# Altium schematic sheet MSP430G2553.SchDoc
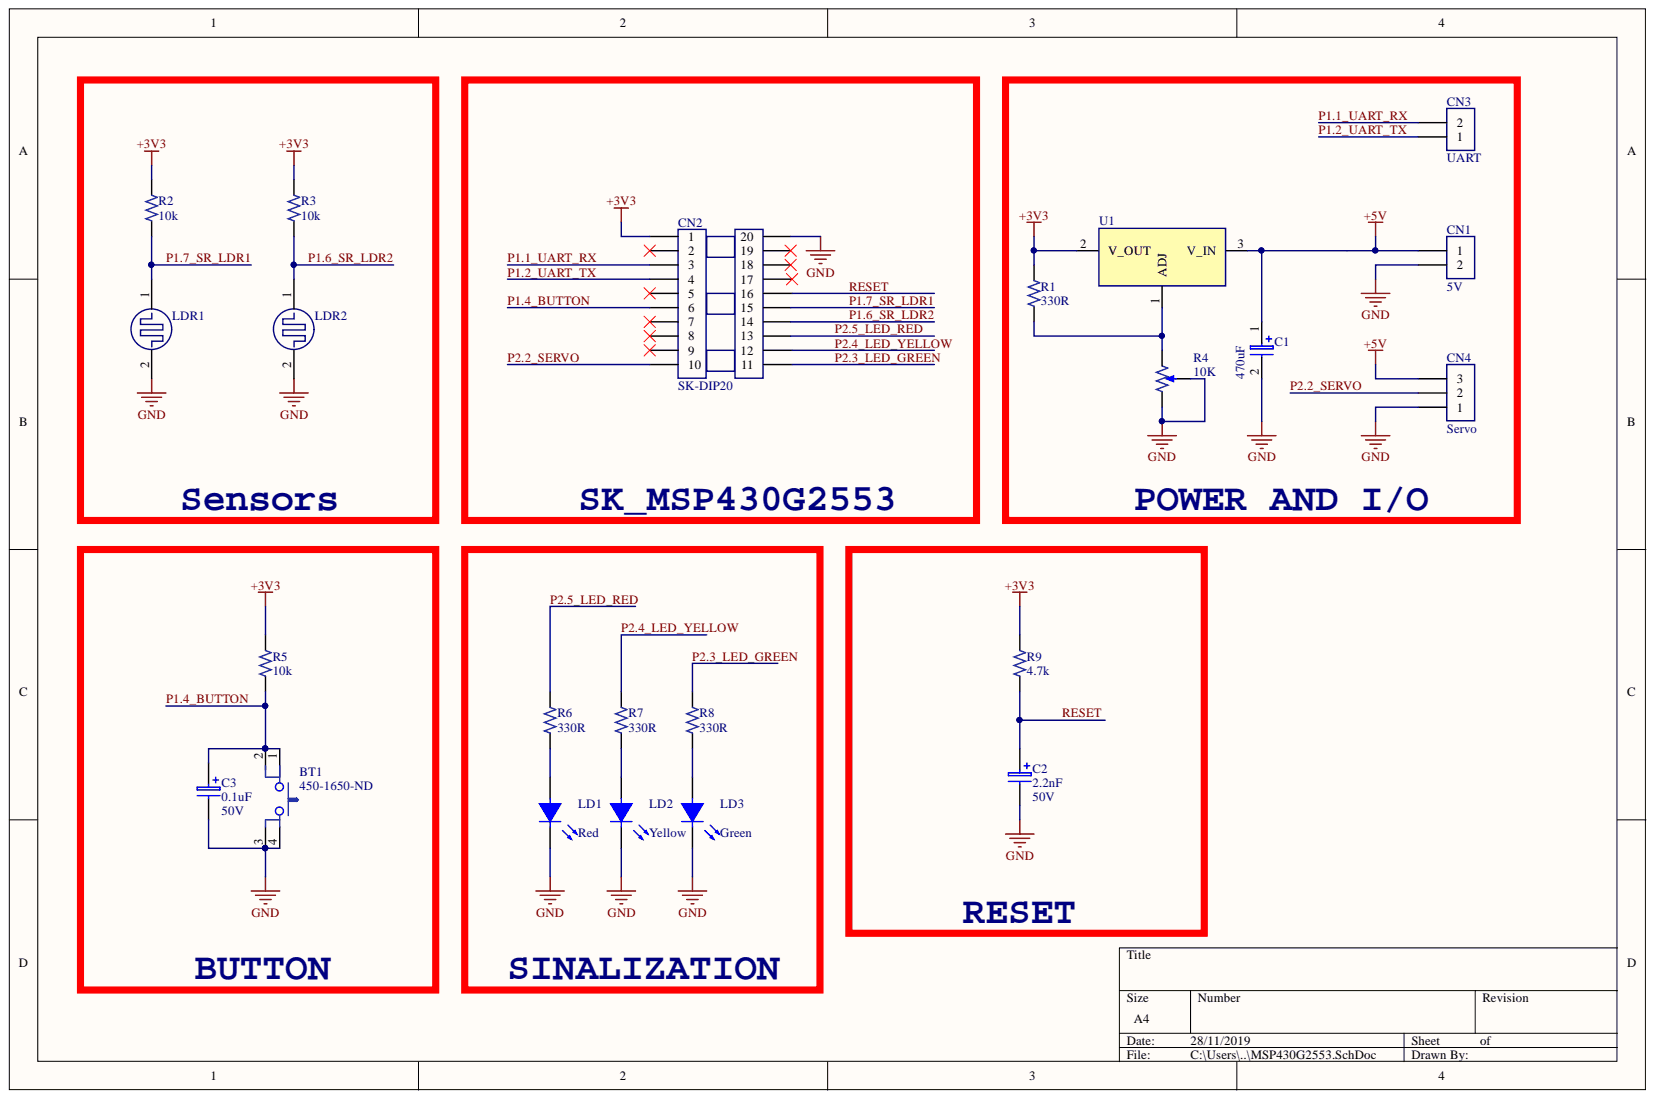
[diagram p0: MSP430G2553.SchDoc]

## Parts
BT1  450-1650-ND  [CH-NA-4P-PTH-430H600L660W-450P-3D]
C1  470uF  [CAP-RADIAL-105x140x40]
C2  2.2nF  Voltage: 50V  [CAP-RADIAL-500Dx1200Hx250P-3D]
C3  0.1uF  Voltage: 50V  [CAP-RADIAL-500Dx1200Hx250P-3D]
CN1  5V  [TERM BLOCK PCB 2POS 5.0MM]
CN2  SK-DIP20  [SK-DIP20]
CN3  UART  [HD-BP-254P-1X02P]
CN4  Servo  [HD-BP-254P-1X03P]
LD1  Red  [LED-PTH-5mm]
LD2  Yellow  [LED-PTH-5mm]
LD3  Green  [LED-PTH-5mm]
LDR1  (no value)  [PDV-P9001 PHT PHOTOCELL]
LDR2  (no value)  [PDV-P9001 PHT PHOTOCELL]
R1  330R  [RES-PTH-H-1200P-1W]
R2  10k  [RES-PTH-H-1200P-1W]
R3  10k  [RES-PTH-H-1200P-1W]
R4  10K  [TRIMMER-PTH-3006P]
R5  10k  [RES-PTH-H-1200P-1W]
R6  330R  [RES-PTH-H-1200P-1W]
R7  330R  [RES-PTH-H-1200P-1W]
R8  330R  [RES-PTH-H-1200P-1W]
R9  4.7k  [RES-PTH-H-1200P-1W]
U1  (no value)  [TO-220-3D]

## Nets
+3V3: CN2.1 R1.2 R2.2 R3.2 R5.2 R9.2 U1.2
+5V: C1.1 CN1.1 CN4.3 U1.3
GND: BT1.3 BT1.4 C1.2 C2.1 C3.1 CN1.2 CN2.20 CN4.1 LD1.2 LD2.2 LD3.2 LDR1.2 LDR2.2 R4.1 R4.2
P1.1_UART_RX: CN2.3 CN3.2
P1.2_UART_TX: CN2.4 CN3.1
P1.4_BUTTON: BT1.1 BT1.2 C3.2 CN2.6 R5.1
P1.6_SR_LDR2: CN2.14 LDR2.1 R3.1
P1.7_SR_LDR1: CN2.15 LDR1.1 R2.1
P2.2_SERVO: CN2.10 CN4.2
P2.3_LED_GREEN: CN2.11 R8.2
P2.4_LED_YELLOW: CN2.12 R7.2
P2.5_LED_RED: CN2.13 R6.2
RESET: C2.2 CN2.16 R9.1
NetLD1_1: LD1.1 R6.1
NetLD2_1: LD2.1 R7.1
NetLD3_1: LD3.1 R8.1
NetR1_1: R1.1 R4.3 U1.1

Not connected: CN2 2 5 7 8 9 17 18 19

## Library data of the parts
BT1 = SWITCH TACTILE SPST-NO 0.05A 24V PTH: Tactile Switch SPST-NO Top Actuated Through Hole; Manufacturer: TE Connectivity Alcoswitch; Manufacturer Part Number: FSM6JSMA; Actuator Length: 3.4mm; Actuator Material: Polyamide; Actuator Type: Standard; Contact Current Rating: 50mA; Contact Resistance: 100mR; Electrical Life: 100000Cycles; Illumination Type: Non-Illuminated; Max Voltage Rating (DC): 12V; Operating Force: 1.57N; Switch Function: Off-Mom; Switch Travel: 0.25mm; Throw Configuration: SPST; User: Reis; Voltage Rating: 24V; Component Kind: Standard; ComponentLink1Description: PTH; ComponentLink1URL: https://www.digikey.com/product-detail/en/te-connectivity-alcoswitch-switches/1825910-6/450-1650-ND/1632536; Contact Material: Copper Alloy; Contact Plating: Silver; Creation Date: 2017/05/08; Current Rating: 50mA; ELV: Compliant; Insulation Resistance: 100MR; Lead Free: Lead Free; Length: 6mm; Max Operating Temperature: 85°C; Mechanical Life: 100000Cycles; Min Operating Temperature: -35°C; Mount: Surface Mount; Orientation: Straight; Package Quantity: 750; Packaging: Loose Piece; Pricing 1: 1 = 0,29000, 10 = 0,28500, 25 = 0,27520, 50 = 0,26520, 100 = 0,25050, 250 = 0,23580, 500 = 0,22598, 1000 = 0,17194, 5000 = 0,14246 (USD); REACH SVHC: Unknown; RoHS: Yes; Size: 1000L600W700H; Stock 1: 34523; Supplier 1: Digi-Key; Supplier Part Number 1: 450-1133-ND; Termination: Gull Wing; Type: Switch; Value: 450-1650-ND; Version: V02; Voltage Rating (DC): 24V; Width: 6mm; Library Name: DiversosPrjLib.IntLib
C1 = 470uF 20% RADIAL 25V: CAP ALUM 470uF 20% 25V RADIAL; Manufacturer 1: Nichicon; Manufacturer Part Number 1: UVR1E471MPD1TD; Dissipation Factor: 0.16%; Lead Diameter: 0.6mm; Leakage Current: 352.5uA; Life (Hours): 2000Hour; Ripple Current: 550mA; User: Rafael Brandani; Capacitance: 470uF; Case/Package: Radial; Component Kind: Standard; ComponentLink1Description: https://datasheet.ciiva.com/96/e-vr-96785.pdf?src-supplier=Digi-Key; ComponentLink1URL: https://datasheet.ciiva.com/96/e-vr-96785.pdf?src-supplier=Digi-Key; ComponentLink2Description: https://datasheet.ciiva.com/5329/e-vr-6088-5329141.pdf?src-supplier=Mouser; ComponentLink2URL: https://datasheet.ciiva.com/5329/e-vr-6088-5329141.pdf?src-supplier=Mouser; ComponentLink3Description: https://datasheet.ciiva.com/16427/e-vr-16427382.pdf?src-supplier=Future+Electronics; ComponentLink3URL: https://datasheet.ciiva.com/16427/e-vr-16427382.pdf?src-supplier=Future+Electronics; ComponentLink4Description: https://datasheet.ciiva.com/14587/70187464-14587722.pdf?src-supplier=Allied+Electronics; ComponentLink4URL: https://datasheet.ciiva.com/14587/70187464-14587722.pdf?src-supplier=Allied+Electronics; ComponentLink5Description: https://datasheet.ciiva.com/11685/e-vr-11685003.pdf?src-supplier=Avnet; ComponentLink5URL: https://datasheet.ciiva.com/11685/e-vr-11685003.pdf?src-supplier=Avnet; ComponentLink6Description: https://datasheet.ciiva.com/15199/getdatasheetpartid-388137-15199243.pdf?src-supplier=Verical; ComponentLink6URL: https://datasheet.ciiva.com/15199/getdatasheetpartid-388137-15199243.pdf?src-supplier=Verical; Creation Date: 2019/09/24; Diameter: 10mm; Height: 12.5mm; Height - Seated (Max): 0.551inch; Lead Pitch: 5mm; Length: 12.5mm; Library Name: CapacitoresPrjLib.IntLib; Max Operating Temperature: 85°C; Min Operating Temperature: -40°C; Mount: Through Hole,PCB; Package Quantity: 500; Packaging: Tape and Reel; Pricing 1: 500=0,11116, 1000=0,09386, 2500=0,08892, 5000=0,08398, 12500=0,07657, 25000=0,07410, 50000=0,06916 (USD); Radiation Hardening: No; REACH SVHC: No SVHC; RoHS: Yes; Stock 1: 11750; Supplier 1: Digi-Key; Supplier Part Number 1: UVR1E471MPD1TD-ND; Termination: Radial; Tolerance: 20%; Version: v0; Voltage: 25V; Voltage Rating: 25V; Voltage Rating (DC): 25V
C2 C3 = 2.2uF 20% RADIAL ALUM 50V: 2.2µF 50V Aluminum Electrolytic Capacitors Radial, Can 1000 Hrs @ 105°C; Manufacturer: Panasonic; Manufacturer Part Number: EEAGA1H2R2H; Capacitance: 2.2uF; Lead Diameter: 0.45mm; Leakage Current: 3uA; Life (Hours): 1000Hour; Ripple Current: 18mA; Tolerance: 20%; User: Gabriel Peres; Case/Package: Radial; Component Kind: Standard; ComponentLink1Description: https://datasheet.ciiva.com/11681/aba0000ce99-11681192.pdf?src-supplier=Avnet; ComponentLink1URL: https://datasheet.ciiva.com/11681/aba0000ce99-11681192.pdf?src-supplier=Avnet; Creation: 2019/05/20; Diameter: 4mm; Features: General Purpose; Height: 7mm; Height - Seated (Max): 0.276inch; Lead Pitch: 2.5mm; Length: 7mm; Library Name: CapacitoresPrjLib.IntLib; Max Operating Temperature: 105°C; Min Operating Temperature: -55°C; Mount: Through Hole; Package Quantity: 1; Packaging: Ammo Pack; Pricing 1: 1 = 0,24000, 10 = 0,16400, 100 = 0,09310, 500 = 0,06568, 1000 = 0,05748 (USD); Radiation Hardening: No; RoHS: Yes; Size: 800DIA1300H; Stock 1: 25646; Supplier 1: Digi-Key; Supplier Part Number 1: P15835CT-ND; Termination: Radial; Version: V01; Voltage Rating: 50V; Voltage Rating (DC): 50V
  C2: Value: 2.2nF
  C3: Value: 0.1uF
CN1 = 1935161  TERM BLOCK PCB 2X1: TERM BLOCK PCB 2POS 5.0MM; User: Rodrigo Balbi; Component Kind: Standard; Creation Date: 2015/11/30; Library Name: Conectores.IntLib; Size: -; Supplier 1: Digikey; Supplier 2: Mouser; Supplier 3: Newarc; Supplier Part Number 1: 277-1667-ND; Supplier Part Number 2: 651-1935161; Supplier Part Number 3: 70R0063; Version: V02
CN2 = SK-DIP20 SOCKET 20 POS 2.54MM: 20 Positions socket, Connector 2,54mm; Manufacturer: Amphenol FCI; Manufacturer Part Number: 20021111-00010T4LF; Connector Type: Male Pin; Contact Post Length: 2.3mm; Contact Resistance: 30mR; Current Rating: 10A; Gender: Male; Insulation Resistance: 100MR; Max Voltage Rating (AC): 125V; Number of Contacts: 10; Pitch: 1.27mm; Row Spacing: 0.05inch; Stack Height: 2.5mm; User: Gabriel Peres; Color: Black; Component Kind: Standard; Contact Material: Nickel; Contact Mating Length: 0.12inch; Contact Plating: Gold; Creation Date: 2019/11/21; Depth: 3.4mm; Housing Color: Black; Housing Material: Plastic; Lead Free: Lead Free; Length: 6.35mm; Library Name: Conectores.IntLib; Max Operating Temperature: 85°C; Min Operating Temperature: -40°C; Mount: Through Hole; Number of Positions: 10; Number of Rows: 2; Orientation: Straight; Package Quantity: 93; Packaging: Rail/Tube; Pricing 1: 1 = 0,67000, 93 = 0,50548, 186 = 0,48435, 558 = 0,42120, 1023 = 0,34749, 5022 = 0,30537, 10044 = 0,28431 (USD); Radiation Hardening: No; REACH SVHC: No SVHC; RoHS: Yes; Stock 1: 13059; Supplier 1: Digi-Key; Supplier Part Number 1: SK-DIP20; Termination: Solder; Value: SK-DIP20; Version: V01; Voltage Rating: 125V
CN3 = 2340-6211TG  CN HDR BP 2X1: CONN HEADER 40POS SGL STR GOLD; Manufacturer: 3M; Connector Type: Male Pin; Current Rating: 3A; Gender: Male; Insulation Resistance: 109R; Number of Contacts: 40; Pitch: 2.54mm; User: Larissa; Color: Black; Component Kind: Standard; ComponentLink1Description: https://datasheet.ciiva.com/7997/227952-7997190.pdf?src-supplier=Element14; ComponentLink1URL: https://datasheet.ciiva.com/7997/227952-7997190.pdf?src-supplier=Element14; Contact Material: Copper Alloy; Contact Mating Length: 0.318inch; Contact Plating: Gold; Creation Date: 2016/05/04; Flammability Rating: UL94 V-0; Housing Material: Thermoplastic; Library Name: Conectores.IntLib; Manufacturer Part Number: 2340-6211TG; Mount: Through Hole; Number of Positions: 40; Number of Rows: 1; Orientation: Straight; Package Quantity: 200; Packaging: Bulk; Pricing 1: 1 = 2,29000, 10 = 2,07800, 100 = 1,78080, 500 = 1,48400, 1000 = 1,27200, 5000 = 1,12784 (USD); REACH SVHC: Unknown; RoHS: Yes; Stock 1: 368; Supplier 1: Digi-Key; Supplier Part Number 1: 3M11929-ND; Termination: Solder; Value: UART; Version: V01
CN4 = 2340-6211TG  CN HDR BP 3X1: CONN HEADER 3 POS 2.54; Manufacturer: Wurth Electronics; Manufacturer Part Number: 61300311121; Connector Type: Male Pin; Gender: Male; Number of Contacts: 3; Pitch: 0.1inch; User: Jean Pierre; Color: Black; Component Kind: Standard; ComponentLink1Description: https://katalog.we-online.de/em/datasheet/6130xx11121.pdf?src-supplier=Digi-Key; ComponentLink1URL: https://katalog.we-online.de/em/datasheet/6130xx11121.pdf?src-supplier=Digi-Key; ComponentLink2Description: http://www.farnell.com/cad/1737268.pdf?src-supplier=Element14; ComponentLink2URL: http://www.farnell.com/cad/1737268.pdf?src-supplier=Element14; Contact Material: Copper; Contact Mating Length: 0.236inch; Contact Plating: Gold; Creation Date: 2017/06/07; Lead Free: Lead Free; Library Name: Conectores.IntLib; Mount: Through Hole; Number of Positions: 3; Number of Rows: 1; Packaging: Bulk; Pricing 1: 1 = 0,13000, 10 = 0,10600, 25 = 0,08800, 50 = 0,07400, 100 = 0,06700, 1000 = 0,06100 (USD); REACH SVHC: Unknown; RoHS: Yes; Size: 808H5462W2578L; Stock 1: 114331; Supplier 1: Digi-Key; Supplier Part Number 1: 732-5316-ND; Termination: Solder; Value: Servo; Version: V04
LD1-LD3 = LTL-307E RED LED PTH 5MM: LED RED DIFF 5MM ROUND T/H; Manufacturer: Vishay Lite-On; Capacitance: 20pF; Current: 3A; Dominant Wavelength: 623nm; Forward Current: 30mA; Forward Voltage: 2V; Lens Style: Dome; Lens Transparency: Diffused; Luminous Intensity: 19mcd; Max Power Dissipation: 100mW; Number of Elements: 1; Reverse Voltage: 5V; Test Current: 10mA; User: Rodrigo Villela; Viewing Angle: 50°; Case/Package: Radial; Color: Red; Component Kind: Standard; ComponentLink1Description: https://datasheet.ciiva.com/19453/697522-19453697.pdf?src-supplier=Jameco; ComponentLink1URL: https://datasheet.ciiva.com/19453/697522-19453697.pdf?src-supplier=Jameco; ComponentLink2Description: https://datasheet.ciiva.com/2112/ltl-307e-2112306.pdf?src-supplier=Digi-Key; ComponentLink2URL: https://datasheet.ciiva.com/2112/ltl-307e-2112306.pdf?src-supplier=Digi-Key; ComponentLink3Description: https://datasheet.ciiva.com/15117/getdatasheetpartid-145550-15117829.pdf?src-supplier=Verical; ComponentLink3URL: https://datasheet.ciiva.com/15117/getdatasheetpartid-145550-15117829.pdf?src-supplier=Verical; ComponentLink4Description: https://datasheet.ciiva.com/16427/lite-onltl-307e-16427350.pdf?src-supplier=Future+Electronics; ComponentLink4URL: https://datasheet.ciiva.com/16427/lite-onltl-307e-16427350.pdf?src-supplier=Future+Electronics; Creation Date: 2015/11/15; Depth: 5.85mm; Diameter: 5mm; Height: 8.6mm; Illumination Color: Red; Lead Free: Lead Free; Length: 5.85mm; Lens Color: Red; Manufacturer Part Number: LTL-307E; Max Operating Temperature: 100°C; Min Operating Temperature: -55°C; Mount: Through Hole; Number of LEDs: 1; Number of Pins: 2; Package Quantity: 1000; Packaging: Bulk; Power Dissipation: 100mW; Pricing 1: 1 = 0,36000, 10 = 0,26200, 25 = 0,19320, 100 = 0,13800, 250 = 0,09384, 500 = 0,08280, 1000 = 0,06486, 2500 = 0,06348, 5000 = 0,05520 (USD); Radiation Hardening: No; RoHS: Yes; Stock 1: 54401; Supplier 1: Digi-Key; Version: V01; Voltage: 2V; Wavelength: 623nm; Width: 5.85mm; Library Name: Opticos.IntLib
  LD1: Value: Red
  LD2: Value: Yellow
  LD3: Value: Green
LDR1 LDR2 = PDV-P9001 PHOTOCELL PTH: PHOTOCELL 4K-11K OHM 4.20MM; Manufacturer: Advanced Photonix; Rise Time: 60ms; User: Larissa; Wavelength: 520nm; Component Kind: Standard; ComponentLink1Description: https://media.digikey.com/pdf/Data%20Sheets/Photonic%20Detetectors%20Inc%20PDFs/PDV-P9001.pdf?src-supplier=Digi-Key; ComponentLink1URL: https://media.digikey.com/pdf/Data%20Sheets/Photonic%20Detetectors%20Inc%20PDFs/PDV-P9001.pdf?src-supplier=Digi-Key; Creation Date: 2015/08/28; Diameter: 4.2mm; Height: 2mm; Housing Material: Ceramic; Lead Free: Contains Lead; Manufacturer Part Number: PDV-P9001; Package Quantity: 500; Pricing 1: 1 = 1,87000, 5 = 1,80000, 10 = 1,46300, 25 = 1,26000, 50 = 1,09120, 100 = 0,99000, 500 = 0,83250, 1000 = 0,74250, 5000 = 0,67500 (USD); RoHS: No; Stock 1: 8009; Supplier 1: Digi-Key; Version: V01; Library Name: Opticos.IntLib
R1-R3 R5-R9 = 13R 5% PR01 MTF 1W: RES 13 OHM 1W 5% AXIAL; Manufacturer: Vishay BCcomponents; Manufacturer Part Number: PR01000101309JR500; Lead Diameter: 0.58mm; Operating Supply Voltage: 350V; Resistance: 13R; Temperature Coefficient: 250ppm/°C; User: Luiz F Siecola; Case/Package: Axial; Component Kind: Standard; ComponentLink1Description: https://datasheet.ciiva.com/7703/44768-7703567.pdf?src-supplier=Element14; ComponentLink1URL: https://datasheet.ciiva.com/7703/44768-7703567.pdf?src-supplier=Element14; Composition: Metal Film; Contact Plating: Tin; Creation Date: 2016/06/15; Diameter: 2.5mm; Features: Flame Retardant Coating; Lead Free: Lead Free; Length: 8mm; Max Operating Temperature: 155°C; Max Power Dissipation: 1W; Min Operating Temperature: -55°C; Number of Pins: 2; Number of Terminations: 2; Package Quantity: 1; Packaging: Tape and Reel; Power Rating: 1W; Pricing 1: 1 = 0,24000, 10 = 0,20600, 25 = 0,14960, 50 = 0,10840, 100 = 0,08040, 250 = 0,06172, 500 = 0,04862, 1000 = 0,03366 (USD); Radiation Hardening: No; REACH SVHC: Unknown; RoHS: Yes; Size: PR01; Stock 1: 17037; Supplier 1: Digi-Key; Supplier Part Number 1: PPC13W-1CT-ND; Termination: Axial; Tolerance: 5%; Version: V01; Voltage Rating: 350V; Voltage Rating (DC): 350V; Width: 2.5mm; Library Name: ResitoresPrjLib.IntLib
  R1 R6-R8: Value: 330R
  R2 R3 R5: Value: 10k
  R9: Value: 4.7k
R4 = TRIMMER 10KOHM 0.75W PC PIN SIDE: 10 kOhms 0.75W, 3/4W PC Pins Through Hole Trimmer Potentiometer Cermet 15 Turn Side Adjustment; Manufacturer: TT BI; Manufacturer Part Number: 91AR10KLF; Max Power Dissipation: 500mW; Number of Turns: 1; Resistance: 10kR; Temperature Coefficient: 100ppm/°C; Tolerance: 20%; User: Reis; Voltage Rating (DC): 250V; Component Kind: Standard; Composition: Cermet; Creation Date: 2017/03/23; Depth: 9.78mm; Height: 0.2inch; Lead Free: Lead Free; Length: 9.53mm; Max Operating Temperature: 125°C; Military Standard: Not; Min Operating Temperature: -55°C; Mount: Through Hole; Package Quantity: 100; Packaging: Bulk; Power Rating: 500mW; Pricing 1: 1 = 1,50000, 10 = 1,32000, 25 = 1,18800, 50 = 1,14400, 100 = 1,10000, 250 = 1,03400, 500 = 0,88000, 1000 = 0,81400, 5000 = 0,66880 (USD); Radiation Hardening: No; REACH SVHC: No SVHC; RoHS: Yes; Stock 1: 0; Supplier 1: Digi-Key; Supplier Part Number 1: 987-1167-ND; Taper: Linear; Termination: Pin; Type: Potentiometer; Value: 10K; Version: V01; Weight: 0.029983oz; Library Name: ResitoresPrjLib.IntLib
U1 = LM317HVT/NOPB: Linear Voltage Regulator IC  1 Output  1.5A TO-220-3; Manufacturer: ON Semiconductor / Fairchild; Manufacturer Part Number: LM7805CT; Accuracy: 4%; Current Rating: 1A; Dropout Voltage: 2V; Max Input Voltage: 35V; Max Output Voltage: 5.2V; Min Input Voltage: 7V; Min Output Voltage: 5V; Number of Outputs: 1; Output Type: Fixed; Output Voltage: 1.25~57v; Polarity: Positive; Power Supply Rejection Ratio (PSRR): 73dB; Quiescent Current: 8mA; User: Gabriel Peres; Case/Package: TO-220-3; Component Kind: Standard; ComponentLink1Description: https://www.digikey.com/product-detail/en/texas-instruments/LM317HVT-NOPB/LM317HVT-NOPB-ND/212662; ComponentLink1URL: https://www.digikey.com/product-detail/en/texas-instruments/LM317HVT-NOPB/LM317HVT-NOPB-ND/212662; Creation Date: 2019/11/21; Height: 16.3mm; Lead Free: Lead Free; Length: 10.67mm; Max Operating Temperature: 125°C; Max Output Current: 1.5A; Min Operating Temperature: -40°C; Mount: Through Hole; Nominal Output Voltage: 5V; Number of Pins: 3; Number of Regulators: 1; Output Current: 1A; Output Voltage Accuracy: 4%; Package Quantity: 50; Packaging: Rail/Tube; Radiation Hardening: No; REACH SVHC: No SVHC; RoHS: Yes; Size: TO-220-3; Stock 1: 0; Supplier 1: Digi-Key; Supplier Part Number 1: LM7805CT-ND; Version: V01; Weight: 1.214g; Width: 4.7mm; Library Name: Circuito Integrado.IntLib
